# Altium schematic sheet MCU.SchDoc
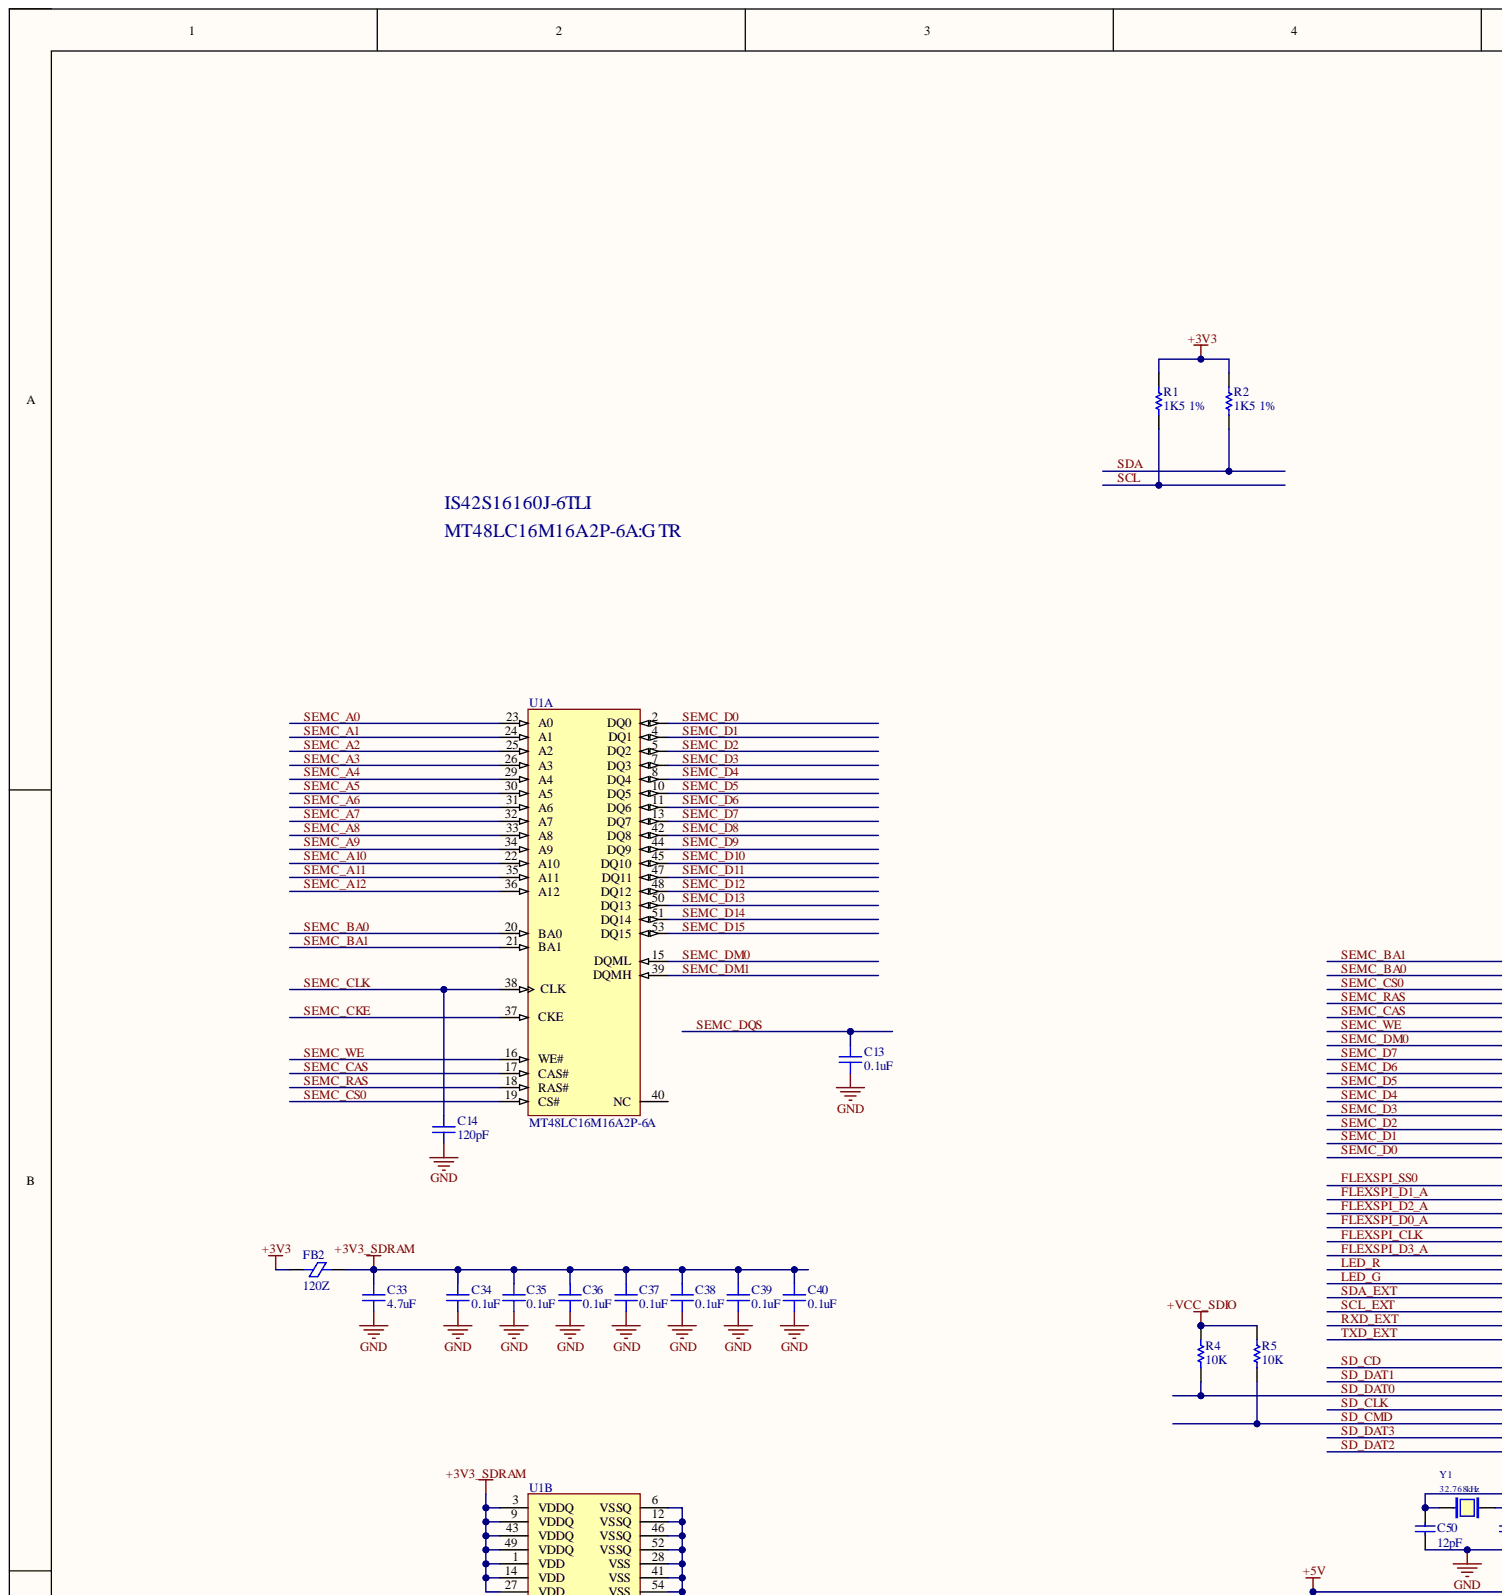
[diagram p0: MCU.SchDoc, top left]
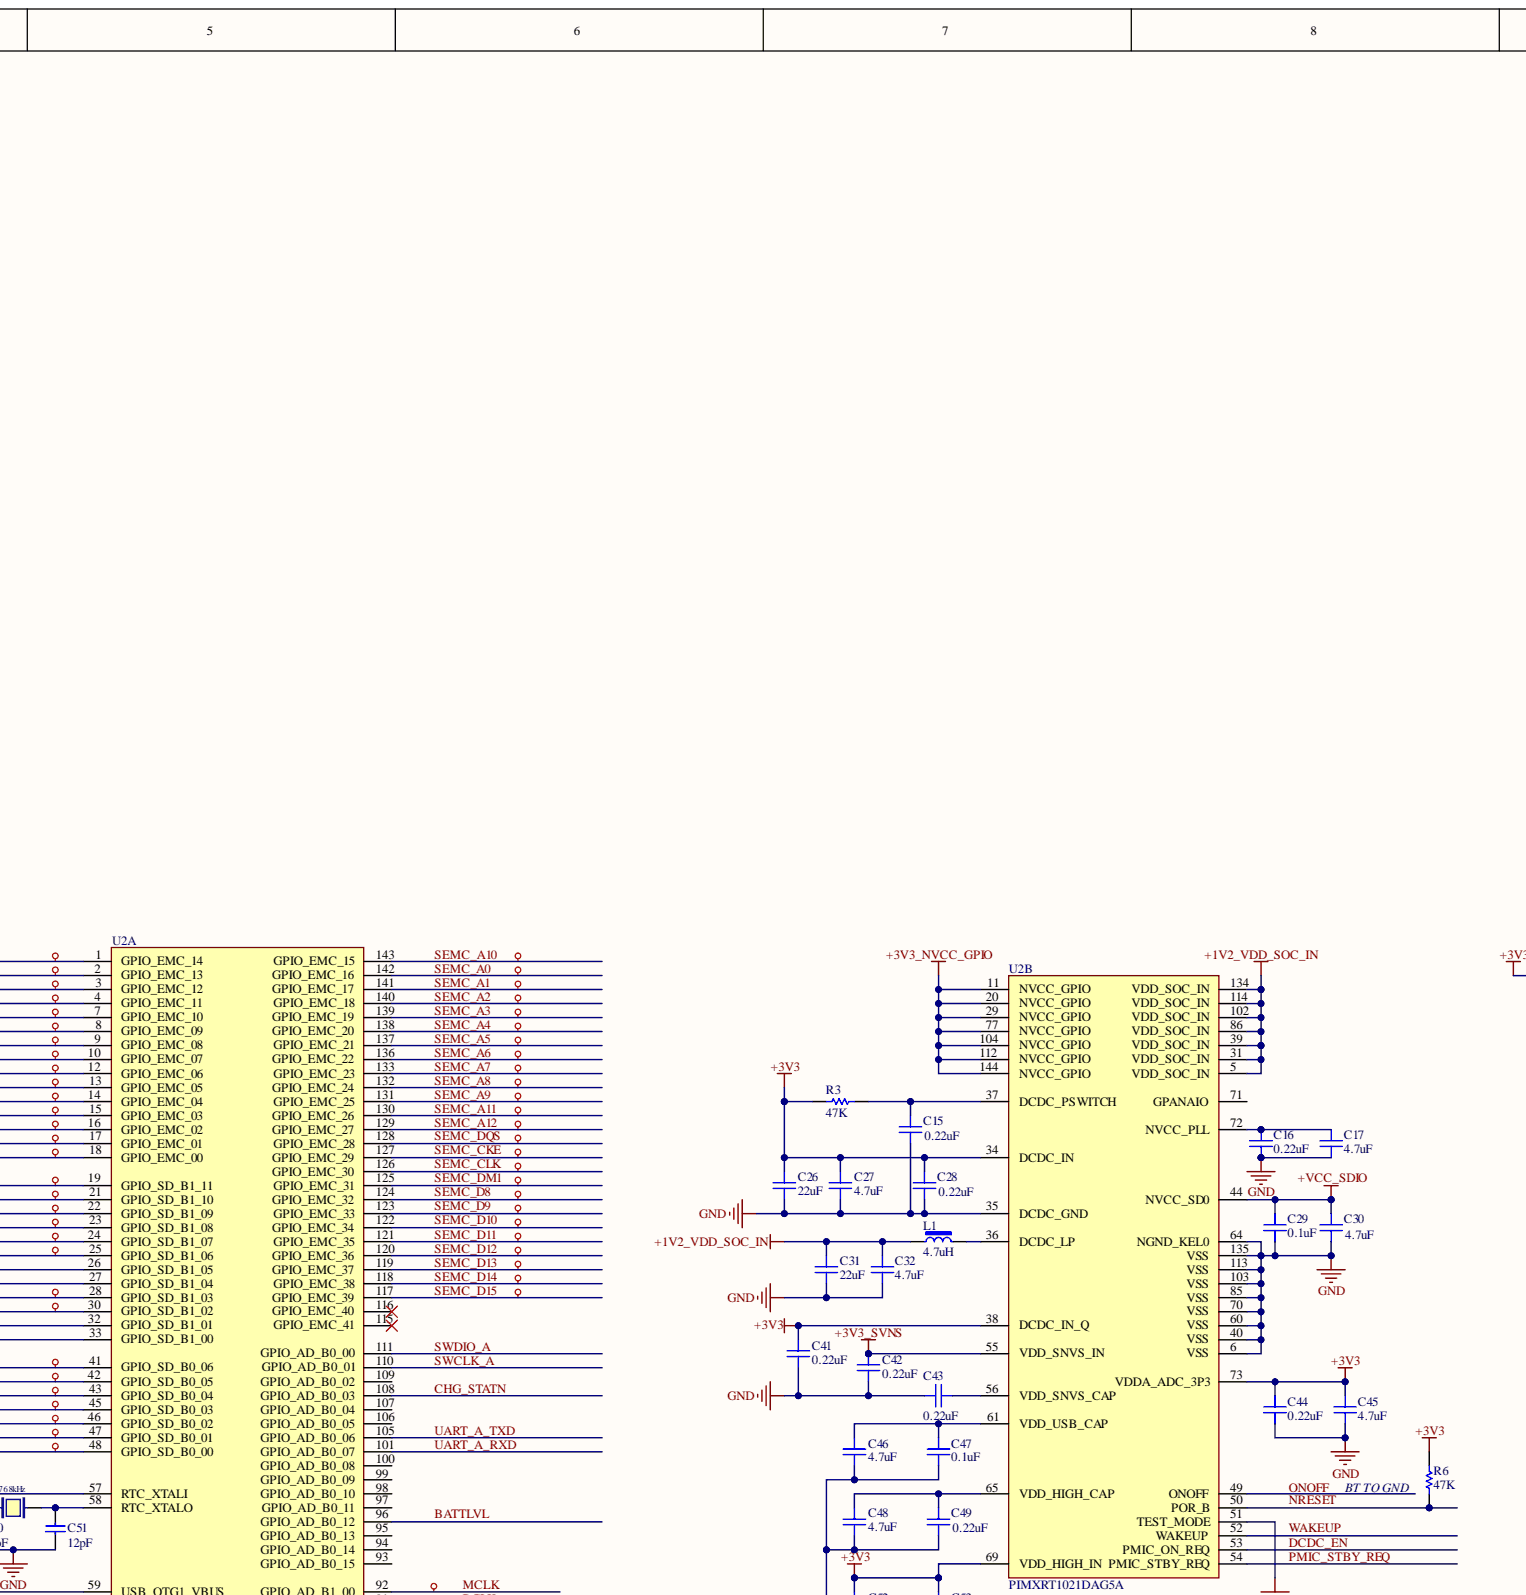
[diagram p1: MCU.SchDoc, top centre]
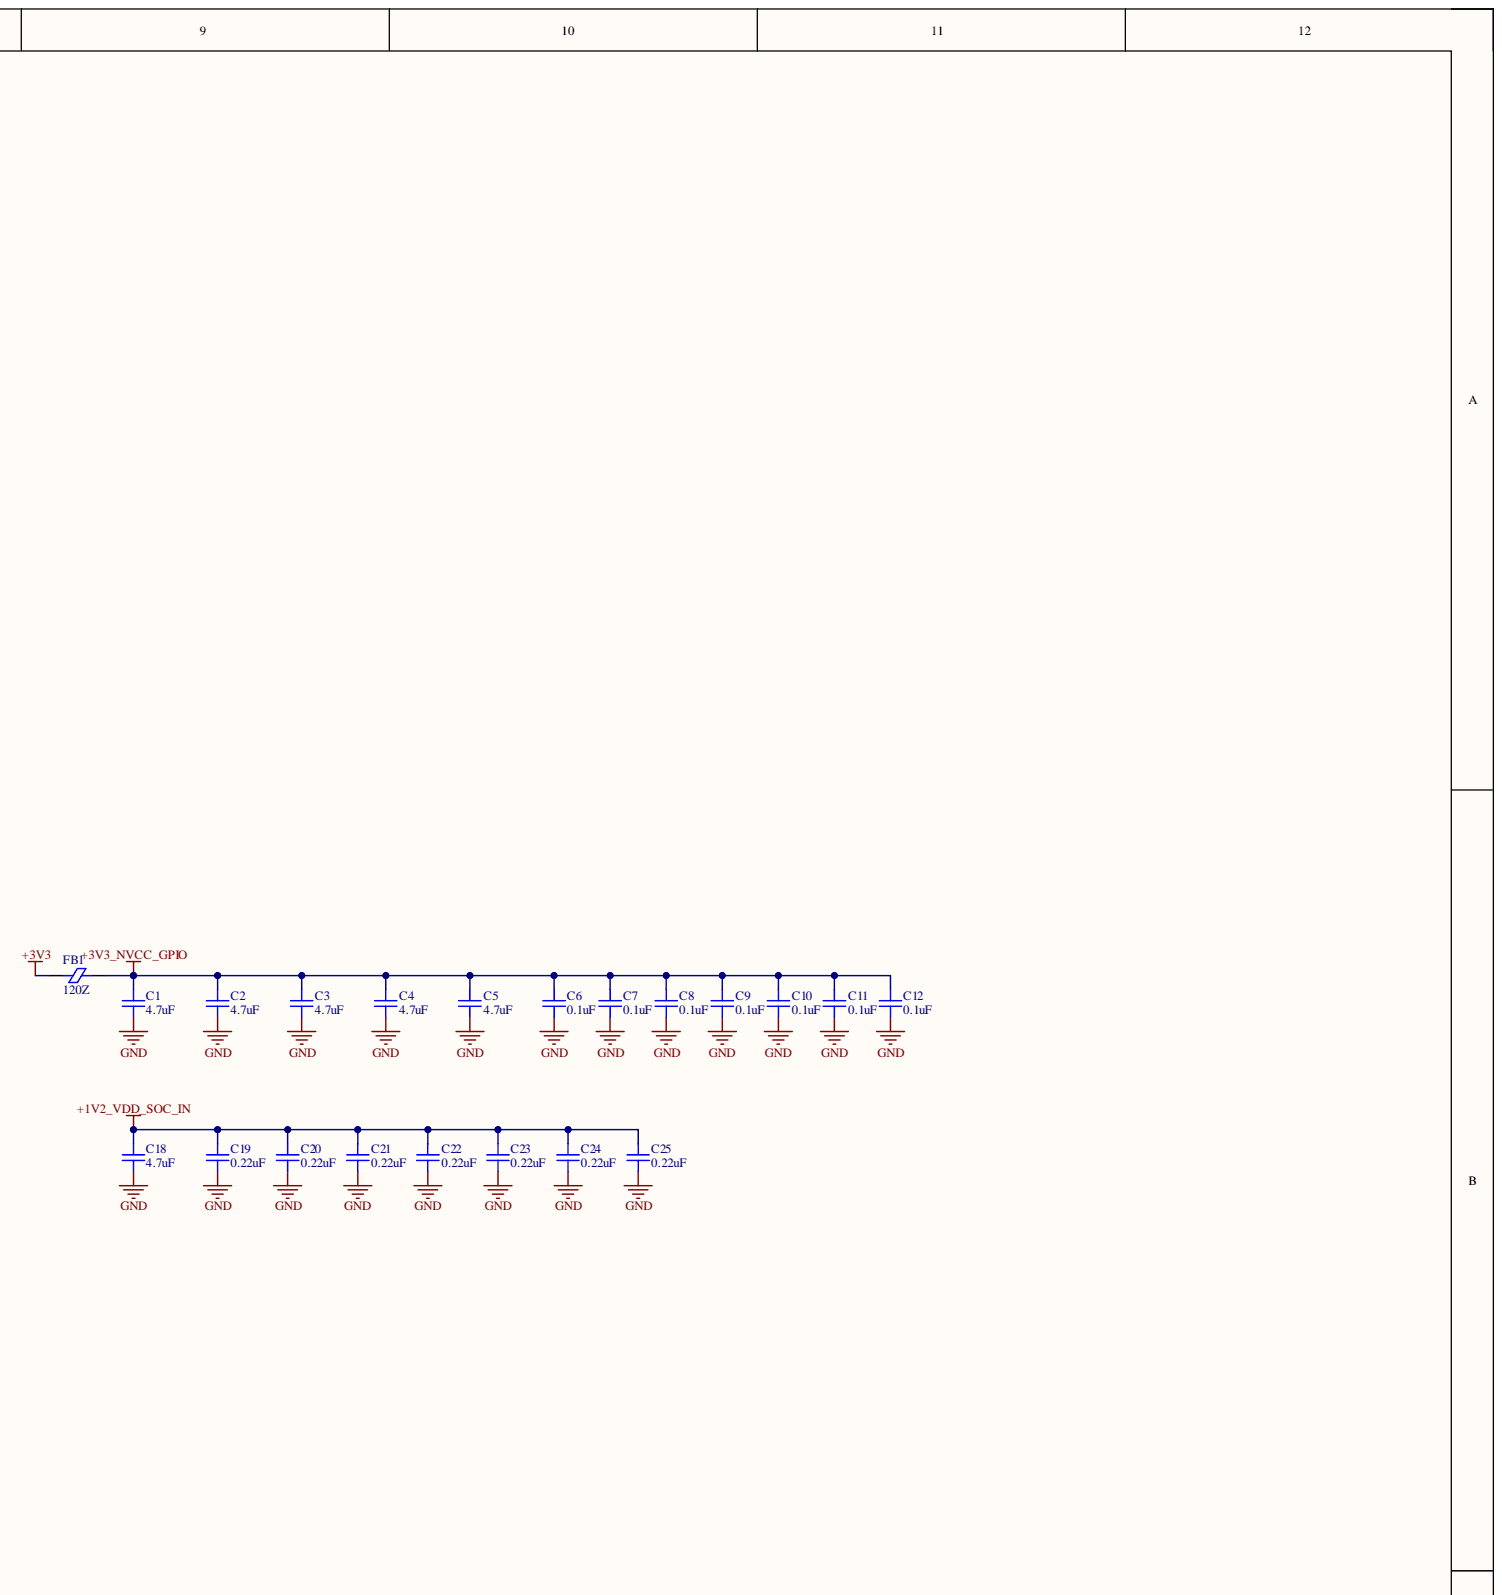
[diagram p2: MCU.SchDoc, top right]
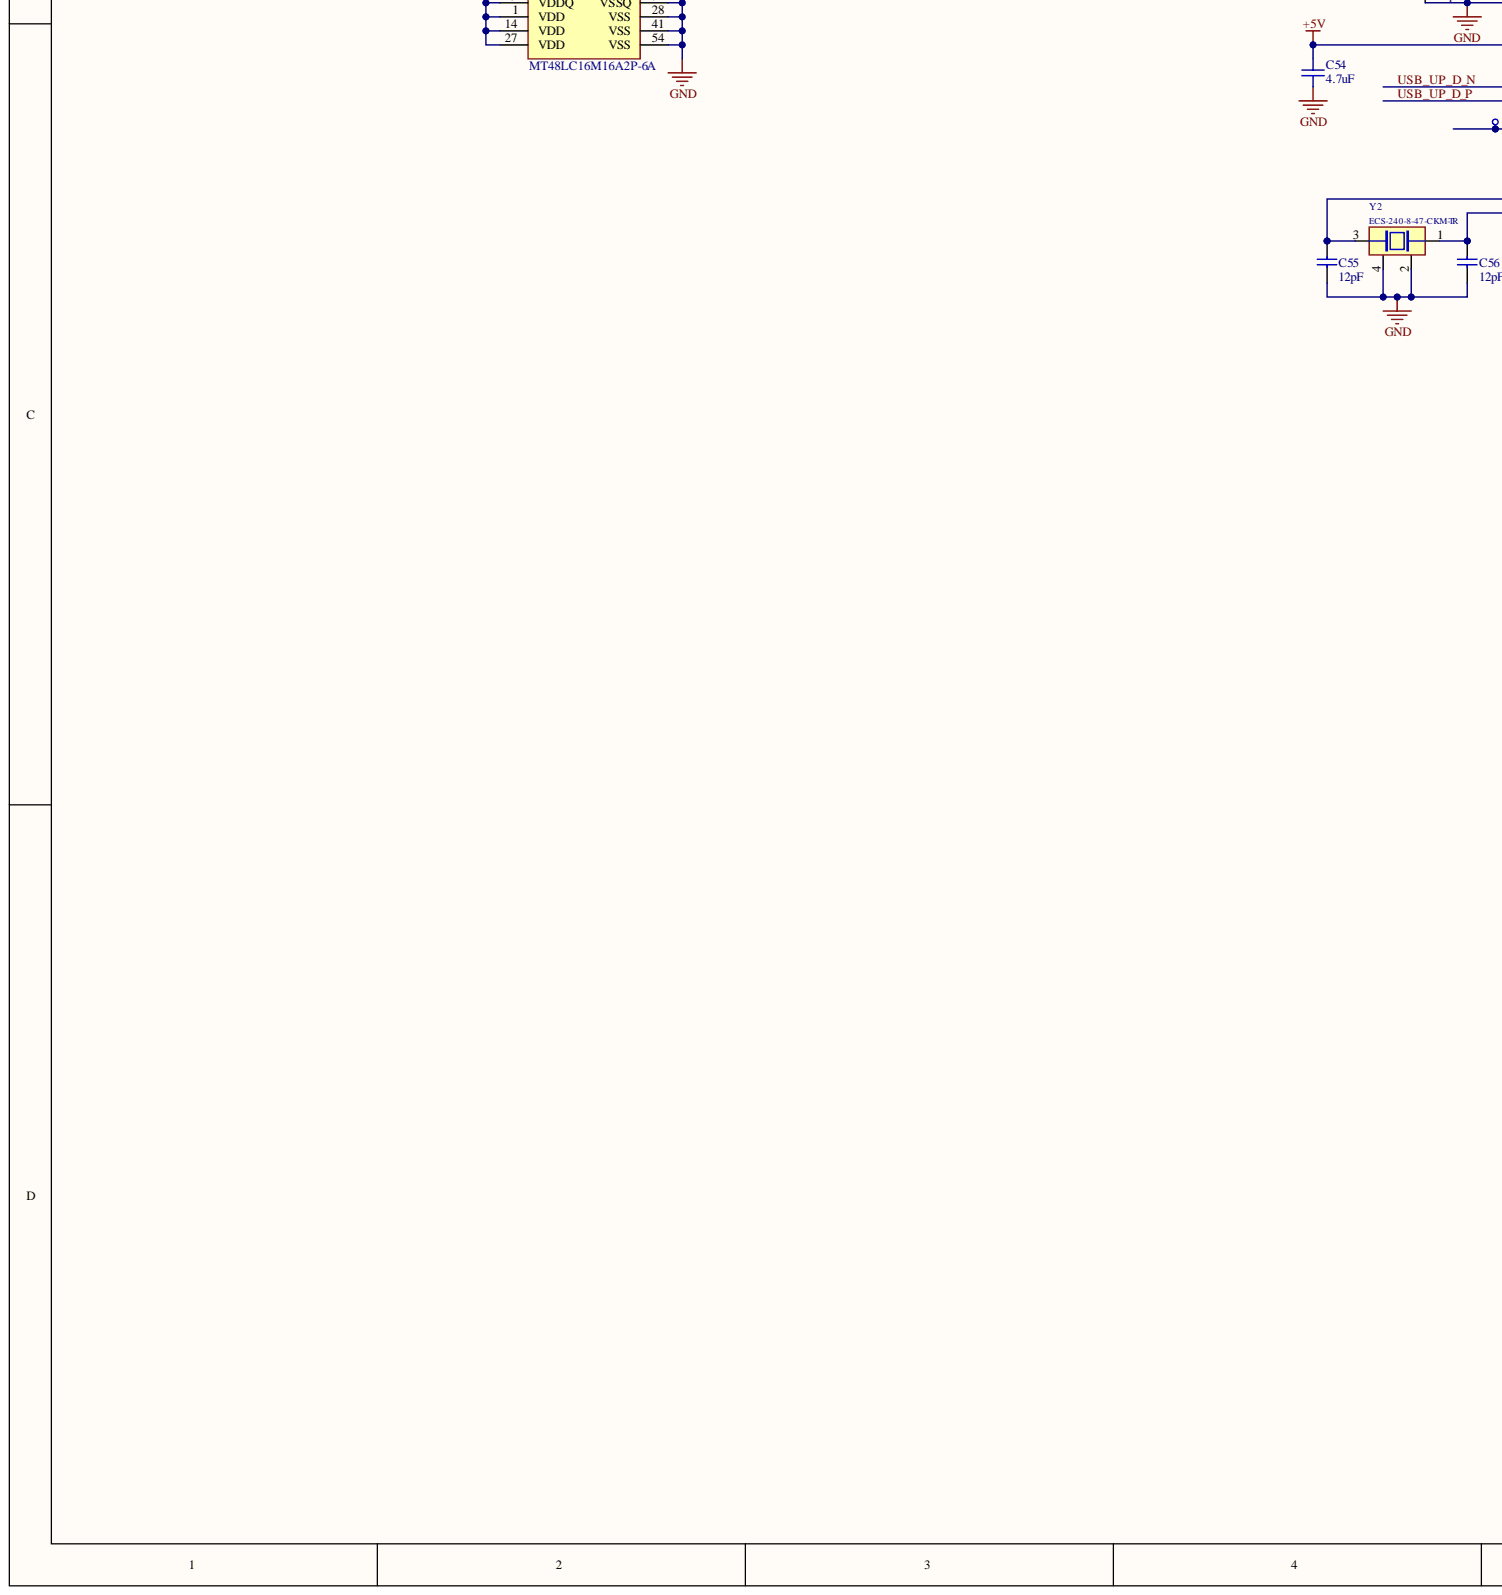
[diagram p3: MCU.SchDoc, bottom left]
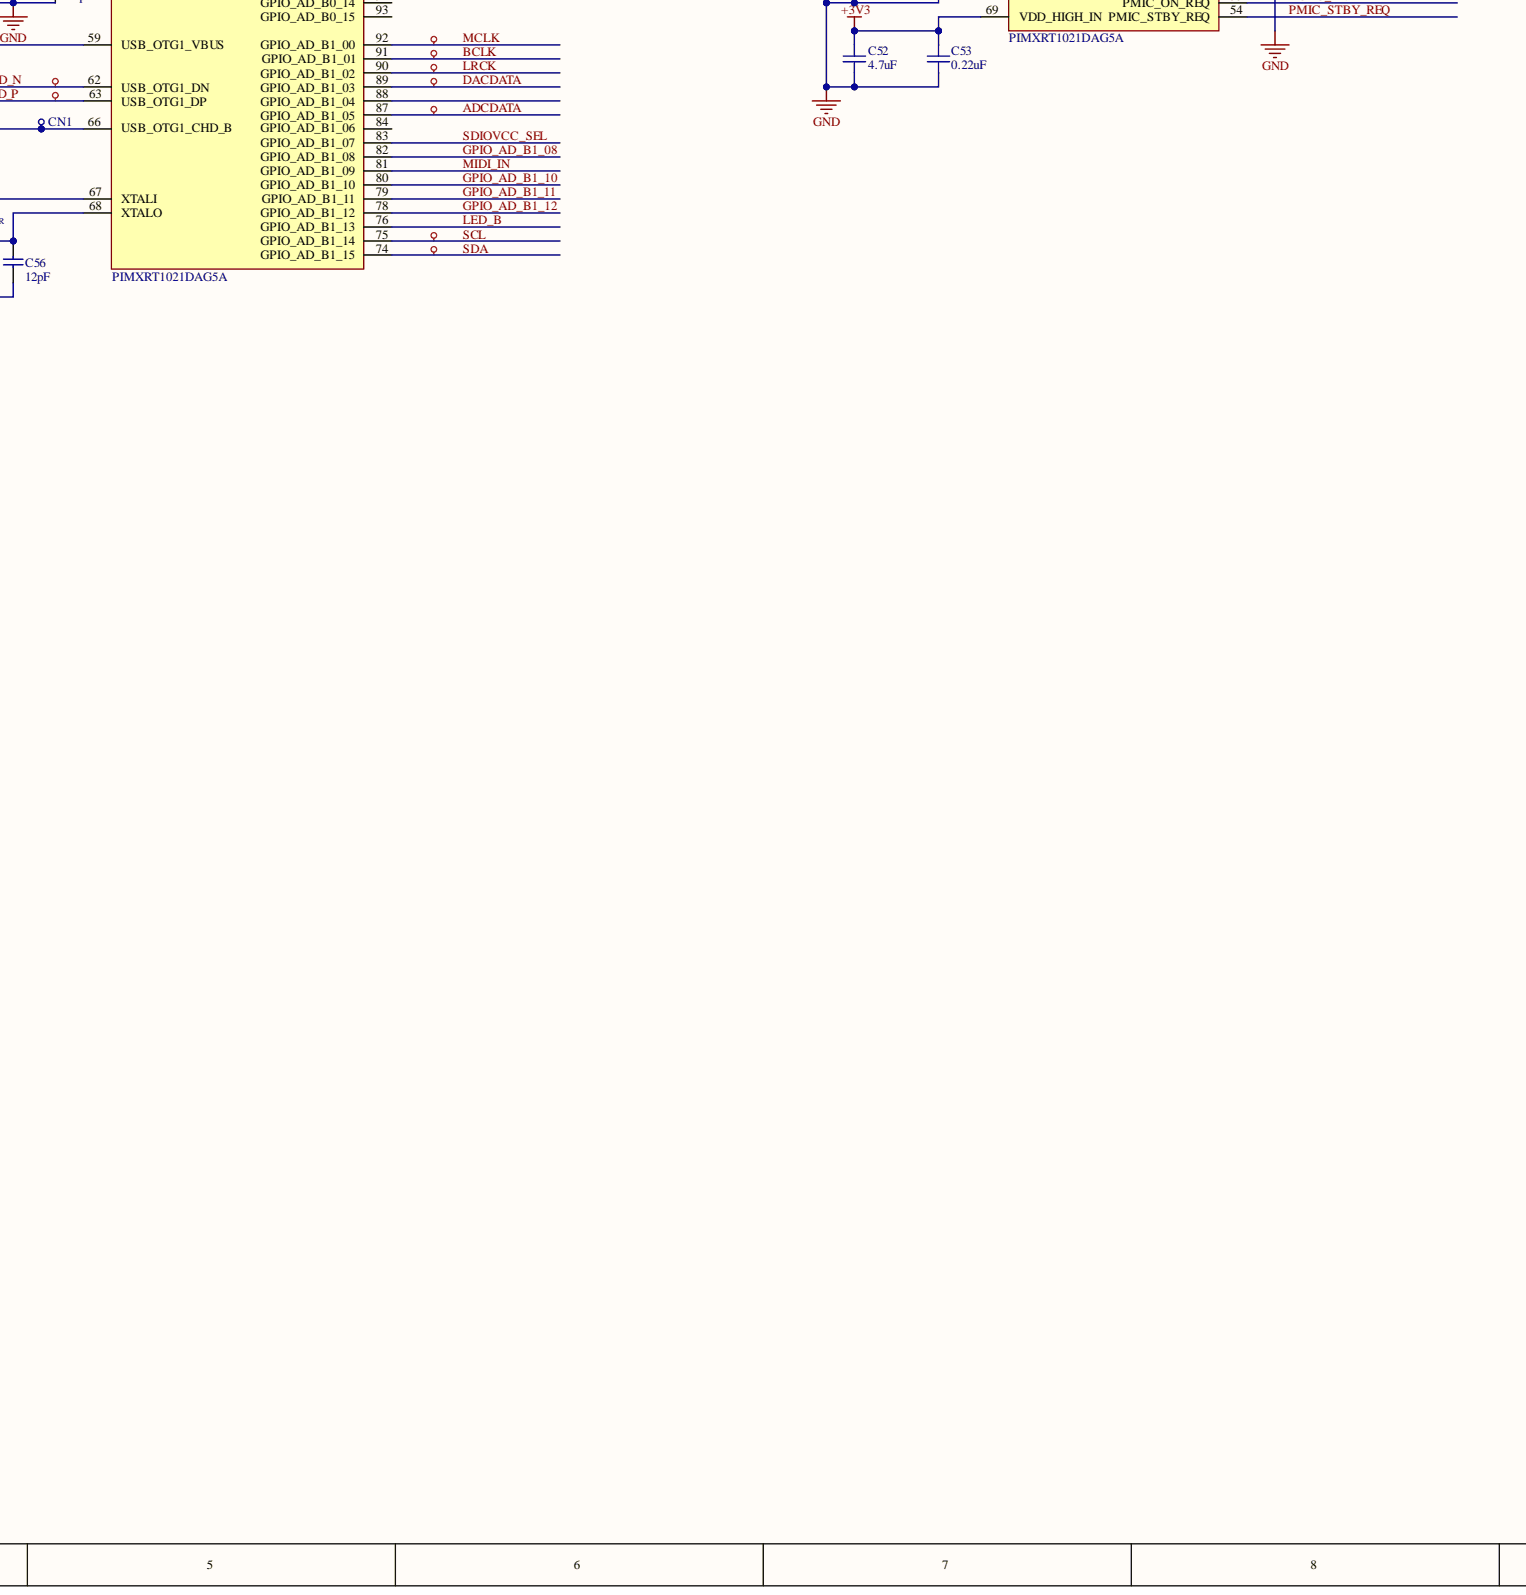
[diagram p4: MCU.SchDoc, bottom centre]
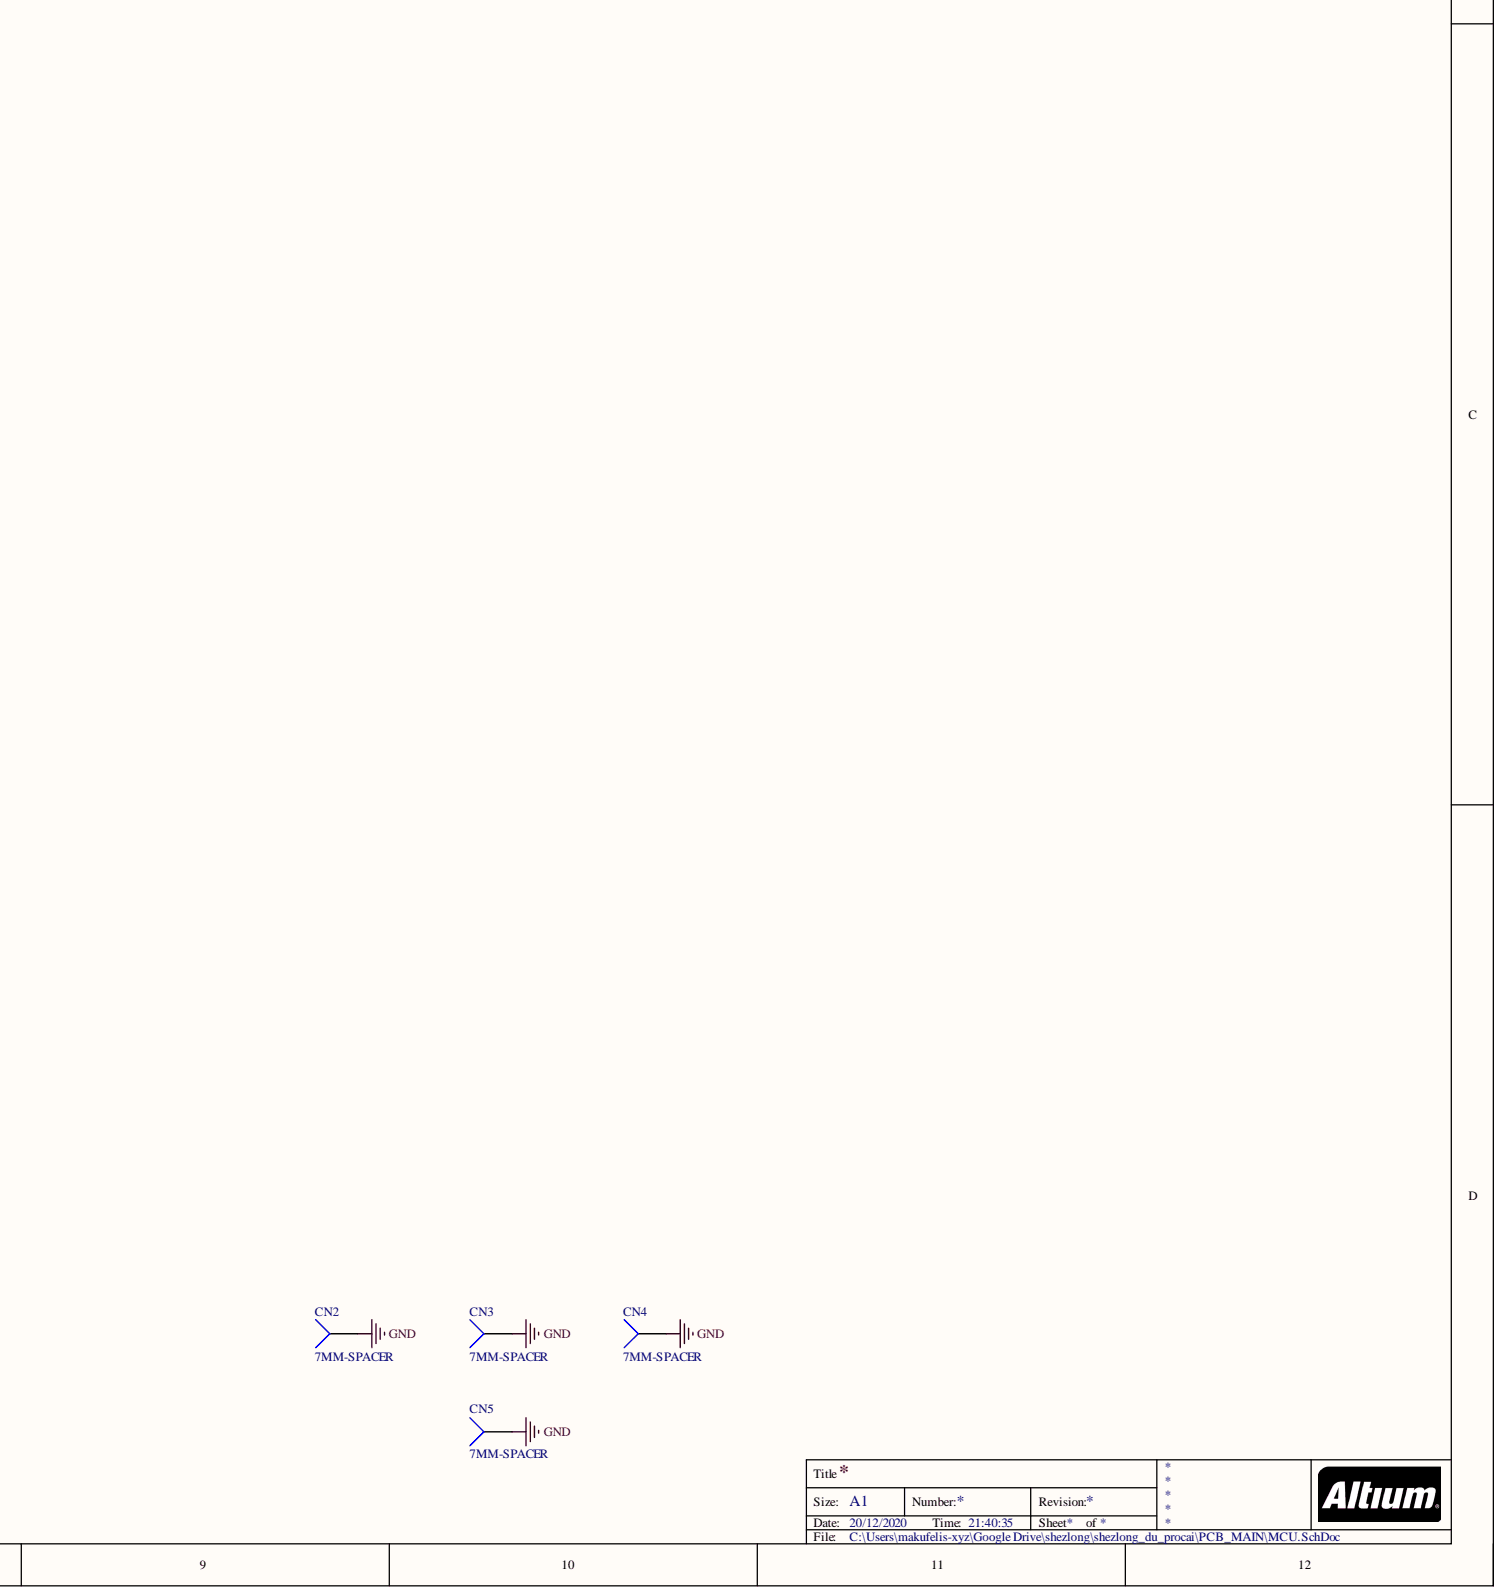
[diagram p5: MCU.SchDoc, bottom right]

## Parts
C1  4.7uF  [AA-0402]
C2  4.7uF  [AA-0402]
C3  4.7uF  [AA-0402]
C4  4.7uF  [AA-0402]
C5  4.7uF  [AA-0402]
C6  0.1uF  [AA-0402]
C7  0.1uF  [AA-0402]
C8  0.1uF  [AA-0402]
C9  0.1uF  [AA-0402]
C10  0.1uF  [AA-0402]
C11  0.1uF  [AA-0402]
C12  0.1uF  [AA-0402]
C13  0.1uF  [AA-0402]
C14  120pF  [AA-0402]
C15  0.22uF  [AA-0402]
C16  0.22uF  [AA-0402]
C17  4.7uF  [AA-0402]
C18  4.7uF  [AA-0402]
C19  0.22uF  [AA-0402]
C20  0.22uF  [AA-0402]
C21  0.22uF  [AA-0402]
C22  0.22uF  [AA-0402]
C23  0.22uF  [AA-0402]
C24  0.22uF  [AA-0402]
C25  0.22uF  [AA-0402]
C26  22uF  [AA-0603]
C27  4.7uF  [AA-0402]
C28  0.22uF  [AA-0402]
C29  0.1uF  [AA-0402]
C30  4.7uF  [AA-0402]
C31  22uF  [AA-0603]
C32  4.7uF  [AA-0402]
C33  4.7uF  [AA-0402]
C34  0.1uF  [AA-0402]
C35  0.1uF  [AA-0402]
C36  0.1uF  [AA-0402]
C37  0.1uF  [AA-0402]
C38  0.1uF  [AA-0402]
C39  0.1uF  [AA-0402]
C40  0.1uF  [AA-0402]
C41  0.22uF  [AA-0402]
C42  0.22uF  [AA-0402]
C43  0.22uF  [AA-0402]
C44  0.22uF  [AA-0402]
C45  4.7uF  [AA-0402]
C46  4.7uF  [AA-0402]
C47  0.1uF  [AA-0402]
C48  4.7uF  [AA-0402]
C49  0.22uF  [AA-0402]
C50  12pF
C51  12pF
C52  4.7uF  [AA-0402]
C53  0.22uF  [AA-0402]
C54  4.7uF  [AA-0402]
C55  12pF
C56  12pF
CN1  TEST POINT  [TESTPAD-1MM]  (value not drawn)  (no BOM)
CN2  7MM-SPACER  [CHAS-2.2MM-GND]
CN3  7MM-SPACER  [CHAS-2.2MM-GND]
CN4  7MM-SPACER  [CHAS-2.2MM-GND]
CN5  7MM-SPACER  [CHAS-2.2MM-GND]
FB1  120Z  [AA-0402]
FB2  120Z  [AA-0402]
L1  4.7uH  [XFL3012]
R1  1K5 1%  [AA-0402]
R2  1K5 1%  [AA-0402]
R3  47K  [AA-0402]
R4  10K  [AA-0402]
R5  10K  [AA-0402]
R6  47K  [AA-0402]
U1  MT48LC16M16A2P-6A  [MICT-P-54_N-TSOP-54]  (parts A,B)
U2  PIMXRT1021DAG5A  [LQFP-144]  (value not drawn)  (parts A,B)
Y1  32.768kHz  [CRYSTAL-ECS-1X1.6]
Y2  ECS-240-8-47-CKM-TR  [CRYSTAL 1.6X1.2]

## Nets
+1V2_VDD_SOC_IN: C18.2 C19.2 C20.2 C21.2 C22.2 C23.2 C24.2 C25.2 C31.2 C32.2 L1.1 U2.5(VDD_SOC_IN) U2.31(VDD_SOC_IN) U2.39(VDD_SOC_IN) U2.86(VDD_SOC_IN) U2.102(VDD_SOC_IN) U2.114(VDD_SOC_IN) U2.134(VDD_SOC_IN)
+3V3: C26.2 C27.2 C28.1 C41.1 C44.1 C45.2 C52.2 C53.1 FB1.1 FB2.1 R1.2 R2.2 R3.2 R6.2 U2.34(DCDC_IN) U2.38(DCDC_IN_Q) U2.69(VDD_HIGH_IN) U2.73(VDDA_ADC_3P3)
+3V3_NVCC_GPIO: C1.2 C2.2 C3.2 C4.2 C5.2 C6.2 C7.2 C8.2 C9.2 C10.2 C11.2 C12.2 FB1.2 U2.11(NVCC_GPIO) U2.20(NVCC_GPIO) U2.29(NVCC_GPIO) U2.77(NVCC_GPIO) U2.104(NVCC_GPIO) U2.112(NVCC_GPIO) U2.144(NVCC_GPIO)
+3V3_SDRAM: C33.2 C34.2 C35.2 C36.2 C37.2 C38.2 C39.2 C40.2 FB2.2 U1.1(VDD) U1.3(VDDQ) U1.9(VDDQ) U1.14(VDD) U1.27(VDD) U1.43(VDDQ) U1.49(VDDQ)
+3V3_SVNS: C42.1 U2.55(VDD_SNVS_IN)
+5V: C54.2 U2.59(USB_OTG1_VBUS)
+VCC_SDIO: C29.2 C30.2 R4.2 R5.2 U2.44(NVCC_SD0)
GND: C1.1 C2.1 C3.1 C4.1 C5.1 C6.1 C7.1 C8.1 C9.1 C10.1 C11.1 C12.1 C13.1 C14.1 C15.2 C16.2 C17.1 C18.1 C19.1 C20.1 C21.1 C22.1 C23.1 C24.1 C25.1 C26.1 C27.1 C28.2 C29.1 C30.1 C31.1 C32.1 C33.1 C34.1 C35.1 C36.1 C37.1 C38.1 C39.1 C40.1 C41.2 C42.2 C43.2 C44.2 C45.1 C46.1 C47.1 C48.1 C49.2 C50.1 C51.1 C52.1 C53.2 C54.1 C55.1 C56.1 CN2.1 CN3.1 CN4.1 CN5.1 U1.6(VSSQ) U1.12(VSSQ) U1.28(VSS) U1.41(VSS) U1.46(VSSQ) U1.52(VSSQ) U1.54(VSS) U2.6(VSS) U2.35(DCDC_GND) U2.40(VSS) U2.51(TEST_MODE) U2.60(VSS) U2.64(NGND_KEL0) U2.70(VSS) U2.85(VSS) U2.103(VSS) U2.113(VSS) U2.135(VSS) Y2.2 Y2.4
ADCDATA: U2.87(GPIO_AD_B1_05)
BATTLVL: U2.96(GPIO_AD_B0_12)
BCLK: U2.91(GPIO_AD_B1_01)
CHG_STATN: U2.108(GPIO_AD_B0_03)
DACDATA: U2.89(GPIO_AD_B1_03)
DCDC_EN: U2.53(PMIC_ON_REQ)
FLEXSPI_CLK: U2.24(GPIO_SD_B1_07)
FLEXSPI_D0_A: U2.23(GPIO_SD_B1_08)
FLEXSPI_D1_A: U2.21(GPIO_SD_B1_10)
FLEXSPI_D2_A: U2.22(GPIO_SD_B1_09)
FLEXSPI_D3_A: U2.25(GPIO_SD_B1_06)
FLEXSPI_SS0: U2.19(GPIO_SD_B1_11)
GPIO_AD_B1_08: U2.82(GPIO_AD_B1_08)
GPIO_AD_B1_10: U2.80(GPIO_AD_B1_10)
GPIO_AD_B1_11: U2.79(GPIO_AD_B1_11)
GPIO_AD_B1_12: U2.78(GPIO_AD_B1_12)
LED_B: U2.76(GPIO_AD_B1_13)
LED_G: U2.27(GPIO_SD_B1_04)
LED_R: U2.26(GPIO_SD_B1_05)
LRCK: U2.90(GPIO_AD_B1_02)
MCLK: U2.92(GPIO_AD_B1_00)
MIDI_IN: U2.81(GPIO_AD_B1_09)
NRESET: R6.1 U2.50(POR_B)
ONOFF: U2.49(ONOFF)
PMIC_STBY_REQ: U2.54(PMIC_STBY_REQ)
RXD_EXT: U2.32(GPIO_SD_B1_01)
SCL: R1.1 U2.75(GPIO_AD_B1_14)
SCL_EXT: U2.30(GPIO_SD_B1_02)
SD_CD: U2.41(GPIO_SD_B0_06)
SD_CLK: U2.45(GPIO_SD_B0_03)
SD_CMD: R5.1 U2.46(GPIO_SD_B0_02)
SD_DAT0: R4.1 U2.43(GPIO_SD_B0_04)
SD_DAT1: U2.42(GPIO_SD_B0_05)
SD_DAT2: U2.48(GPIO_SD_B0_00)
SD_DAT3: U2.47(GPIO_SD_B0_01)
SDA: R2.1 U2.74(GPIO_AD_B1_15)
SDA_EXT: U2.28(GPIO_SD_B1_03)
SDIOVCC_SEL: U2.83(GPIO_AD_B1_07)
SEMC_A0: U1.23(A0) U2.142(GPIO_EMC_16)
SEMC_A1: U1.24(A1) U2.141(GPIO_EMC_17)
SEMC_A2: U1.25(A2) U2.140(GPIO_EMC_18)
SEMC_A3: U1.26(A3) U2.139(GPIO_EMC_19)
SEMC_A4: U1.29(A4) U2.138(GPIO_EMC_20)
SEMC_A5: U1.30(A5) U2.137(GPIO_EMC_21)
SEMC_A6: U1.31(A6) U2.136(GPIO_EMC_22)
SEMC_A7: U1.32(A7) U2.133(GPIO_EMC_23)
SEMC_A8: U1.33(A8) U2.132(GPIO_EMC_24)
SEMC_A9: U1.34(A9) U2.131(GPIO_EMC_25)
SEMC_A10: U1.22(A10) U2.143(GPIO_EMC_15)
SEMC_A11: U1.35(A11) U2.130(GPIO_EMC_26)
SEMC_A12: U1.36(A12) U2.129(GPIO_EMC_27)
SEMC_BA0: U1.20(BA0) U2.2(GPIO_EMC_13)
SEMC_BA1: U1.21(BA1) U2.1(GPIO_EMC_14)
SEMC_CAS: U1.17(CAS#) U2.7(GPIO_EMC_10)
SEMC_CKE: U1.37(CKE) U2.127(GPIO_EMC_29)
SEMC_CLK: C14.2 U1.38(CLK) U2.126(GPIO_EMC_30)
SEMC_CS0: U1.19(CS#) U2.3(GPIO_EMC_12)
SEMC_D0: U1.2(DQ0) U2.18(GPIO_EMC_00)
SEMC_D1: U1.4(DQ1) U2.17(GPIO_EMC_01)
SEMC_D2: U1.5(DQ2) U2.16(GPIO_EMC_02)
SEMC_D3: U1.7(DQ3) U2.15(GPIO_EMC_03)
SEMC_D4: U1.8(DQ4) U2.14(GPIO_EMC_04)
SEMC_D5: U1.10(DQ5) U2.13(GPIO_EMC_05)
SEMC_D6: U1.11(DQ6) U2.12(GPIO_EMC_06)
SEMC_D7: U1.13(DQ7) U2.10(GPIO_EMC_07)
SEMC_D8: U1.42(DQ8) U2.124(GPIO_EMC_32)
SEMC_D9: U1.44(DQ9) U2.123(GPIO_EMC_33)
SEMC_D10: U1.45(DQ10) U2.122(GPIO_EMC_34)
SEMC_D11: U1.47(DQ11) U2.121(GPIO_EMC_35)
SEMC_D12: U1.48(DQ12) U2.120(GPIO_EMC_36)
SEMC_D13: U1.50(DQ13) U2.119(GPIO_EMC_37)
SEMC_D14: U1.51(DQ14) U2.118(GPIO_EMC_38)
SEMC_D15: U1.53(DQ15) U2.117(GPIO_EMC_39)
SEMC_DM0: U1.15(DQML) U2.9(GPIO_EMC_08)
SEMC_DM1: U1.39(DQMH) U2.125(GPIO_EMC_31)
SEMC_DQS: C13.2 U2.128(GPIO_EMC_28)
SEMC_RAS: U1.18(RAS#) U2.4(GPIO_EMC_11)
SEMC_WE: U1.16(WE#) U2.8(GPIO_EMC_09)
SWCLK_A: U2.110(GPIO_AD_B0_01)
SWDIO_A: U2.111(GPIO_AD_B0_00)
TXD_EXT: U2.33(GPIO_SD_B1_00)
UART_A_RXD: U2.101(GPIO_AD_B0_07)
UART_A_TXD: U2.105(GPIO_AD_B0_06)
USB_UP_D_N: U2.62(USB_OTG1_DN)
USB_UP_D_P: U2.63(USB_OTG1_DP)
WAKEUP: U2.52(WAKEUP)
NetC15_1: C15.1 R3.1 U2.37(DCDC_PSWITCH)
NetC16_1: C16.1 C17.2 U2.72(NVCC_PLL)
NetC43_1: C43.1 U2.56(VDD_SNVS_CAP)
NetC46_2: C46.2 C47.2 U2.61(VDD_USB_CAP)
NetC48_2: C48.2 C49.1 U2.65(VDD_HIGH_CAP)
NetC50_2: C50.2 U2.57(RTC_XTALI) Y1.1
NetC51_2: C51.2 U2.58(RTC_XTALO) Y1.2
NetC55_2: C55.2 U2.67(XTALI) Y2.3
NetC56_2: C56.2 U2.68(XTALO) Y2.1
NetCN1_TST: CN1.TST U2.66(USB_OTG1_CHD_B)
NetL1_2: L1.2 U2.36(DCDC_LP)

Not connected: U1 40(NC); U2 71(GPANAIO) 84(GPIO_AD_B1_06) 88(GPIO_AD_B1_04) 93(GPIO_AD_B0_15) 94(GPIO_AD_B0_14) 95(GPIO_AD_B0_13) 97(GPIO_AD_B0_11) 98(GPIO_AD_B0_10) 99(GPIO_AD_B0_09) 100(GPIO_AD_B0_08) 106(GPIO_AD_B0_05) 107(GPIO_AD_B0_04) 109(GPIO_AD_B0_02) 115(GPIO_EMC_41) 116(GPIO_EMC_40)

## Library data of the parts
C1-C13 C15-C49 C52-C54 = 00005453: Database Table Name: Capacitor; Component Kind: Standard; Library Name: ALT_DB.DBLIB
  C1-C5 C17 C18 C27 C30 C32 C33 C45 C46 C48 C52 C54: Value: 4.7uF
  C6-C13 C29 C34-C40 C47: Value: 0.1uF
C14 = 00005453: 120pF 50V +-5% COG  0402  -55+125; Manufacturer: MURATA; Manufacturer Part Number: GRM1555C1H121JA01D; Allegro PCB Footprint: c1005_TM2; COMPHEIGHT: 0.5; Database Table Name: Capacitor; Komentaras: $; ActivepartsID: http://search.murata.co.jp/Ceramy/image/img/PDF/ENG/GRM1555C1H121JA01.pdf; Availability: In Stock; Component Kind: Standard; Data: 10/11/2010; Distributor: Digi-Key; Distributor Part Number: 490-1292-2-ND; Library Name: ALT_DB.DBLIB; Package: 10000T&R; Part Number: 00005453; Part Type: Ceramic\1005; Price: 0.005; SMD: Yes; Tolerance: +-5%; Value: 120pF; Voltage: 50V; Datasheet: E:\pdf\KONDENSATORIAI\CER_smd\GRM1555C1H121JA01.pdf
C50 C51 C55 C56 = C0402-100n: Manufacturer: Murata Electronics; Component Kind: Standard; Library Name: Nordic Passive DB.DbLib; Value: 12pF
CN1 = TESTPOINT: Library Name: ULTIMA_LIB.SCHLIB
CN2-CN5 = Socket: Manufacturer: Wurth Electronics Inc.; Manufacturer Part Number: 9774070243R; Component Kind: Standard; Library Name: Miscellaneous Connectors.IntLib
FB1 FB2 = F_BEAD: PSpiceTemplate: L^@REFDES %1 %2 ?TOLERANCE|L^@REFDES| @VALUE ?IC/IC=@IC/ ?TOLERANCE|\n.model l^@REFDES IND L=1 DEV=@TOLERANCE%|; Component Kind: Standard; Simulation: L_0402; Library Name: ULTIMA_LIB.SCHLIB
L1 = 20100044D: Manufacturer: Littelfuse; Manufacturer Part Number: LPWI201610SR47T; Allegro PCB Footprint: DFE_20x16x09; COMPHEIGHT: 1.0; Database Table Name: Inductors; Gaminys: 8DEV; Komentaras: $; Tolerance: 20%; Value: 0.47uH 3.5A; ActivepartsID: https://eu.mouser.com/datasheet/2/240/Littelfuse_Power_Inductor_LPWI_Datasheet.pdf-1372344.pdf; Component Kind: Standard; Data: 9/20/2019; Distributor: Mouser; Distributor Part Number: 576-LPWI201610SR47T; Library Name: ALT_DB.DBLIB; Package: 3000T&R; Part Number: 20100044D; Part Type: Power Inductor\Shielded; Price: 0.11342; SMD: Yes; Datasheet: E:\pdf\induktyvumai\smd\Littelfuse_Power_Inductor_LPWI_Datasheet.pdf-1372344.pdf
R1 R2 = Res1: Manufacturer: Panasonic; Component Kind: Standard; Signal Integrity: Res; Simulation: RESISTOR; Value: 1K5 1%; Library Name: ULTIMA_LIB.SCHLIB
R3-R6 = 10012076Wa: Database Table Name: Resistor; Component Kind: Standard; Library Name: ALT_DB.DBLIB; Signal Integrity: Res; Simulation: RESISTOR
  R3 R6: Value: 47K
  R4 R5: Value: 10K
U1 = MT48LC16M16A2P-6A: 256Mb PC133 SDR SDRAM, 16Mb x 16, 6ns, 3.3V, 0 to 70 degC, 54-Pin TSOP, Pb-Free; Manufacturer: Micron; CL: CL = 3; Clock Rate: 167 MHz; Op. Temp.: 0C to +70C; Component Kind: Standard; ComponentLink1Description: Manufacturer URL; ComponentLink1URL: http://www.micron.com/; ComponentLink2Description: Datasheet; ComponentLink2URL: http://www.micron.com/~/media/Documents/Products/Data%20Sheet/DRAM/256Mb_sdr.pdf; ComponentLink3Description: Package Specification; ComponentLink3URL: http://www.micron.com/~/media/Documents/Products/Data%20Sheet/DRAM/128mb_x4x8x16_sdram.pdf; Cycle Time: 6ns; Data Rate: PC133; DatasheetVersion: Rev.Q; Density: 256Mb; Depth: 16Mb; Library Name: Micron Memory SDRAM.IntLib; Mounting Technology: Surface Mount; PackageDescription: 54-Pin Thin Small Outline Package, 10.16 x 22.22 mm, Pitch 0.8 mm; PackageReference: MICT-P-54; PackageVersion: Rev.Q; PartNumber: MT48LC16M16A2P-6A; RoHS: Yes; Voltage: 3.3V; Width: x16
Y2 = (no symbol name): Manufacturer: EPSON; Manufacturer Part Number: FA-20H 12.0000MB30Z-W; Part Number: 25000266G; Allegro PCB Footprint: CX2520SB; COMPHEIGHT: 0.45; Gaminys: IGN; Komentaras: $; Value: 12.00000 MHz+-30ppm; ActivepartsID: https://support.epson.biz/td/api/doc_check.php?dl=brief_FA-20H_en.pdf; Component Kind: Standard; Data: 26/03/2018; Distributor: Digi-Key; Distributor Part Number: FA-20H12.0000MB30Z-W-ND; Part Type: Quartz crystal\SMD 2.5x2.0; Price: 0.42; SMD: Yes; Datasheet: E:\pdf\osciliatoriai\FA-20H_en.pdf; Library Name: ULTIMA_LIB.SCHLIB
U2 = PIMXRT1021DAG5A: Component Kind: Standard; Library Name: ULTIMA_LIB.SCHLIB
Y1 = (no symbol name): Component Kind: Standard; Value: 32.768kHz; Library Name: ULTIMA_LIB.SCHLIB
